# Altium schematic sheet 5.04.51805A02_RKPort.SchDoc(5.04.51805A02_RKPort)
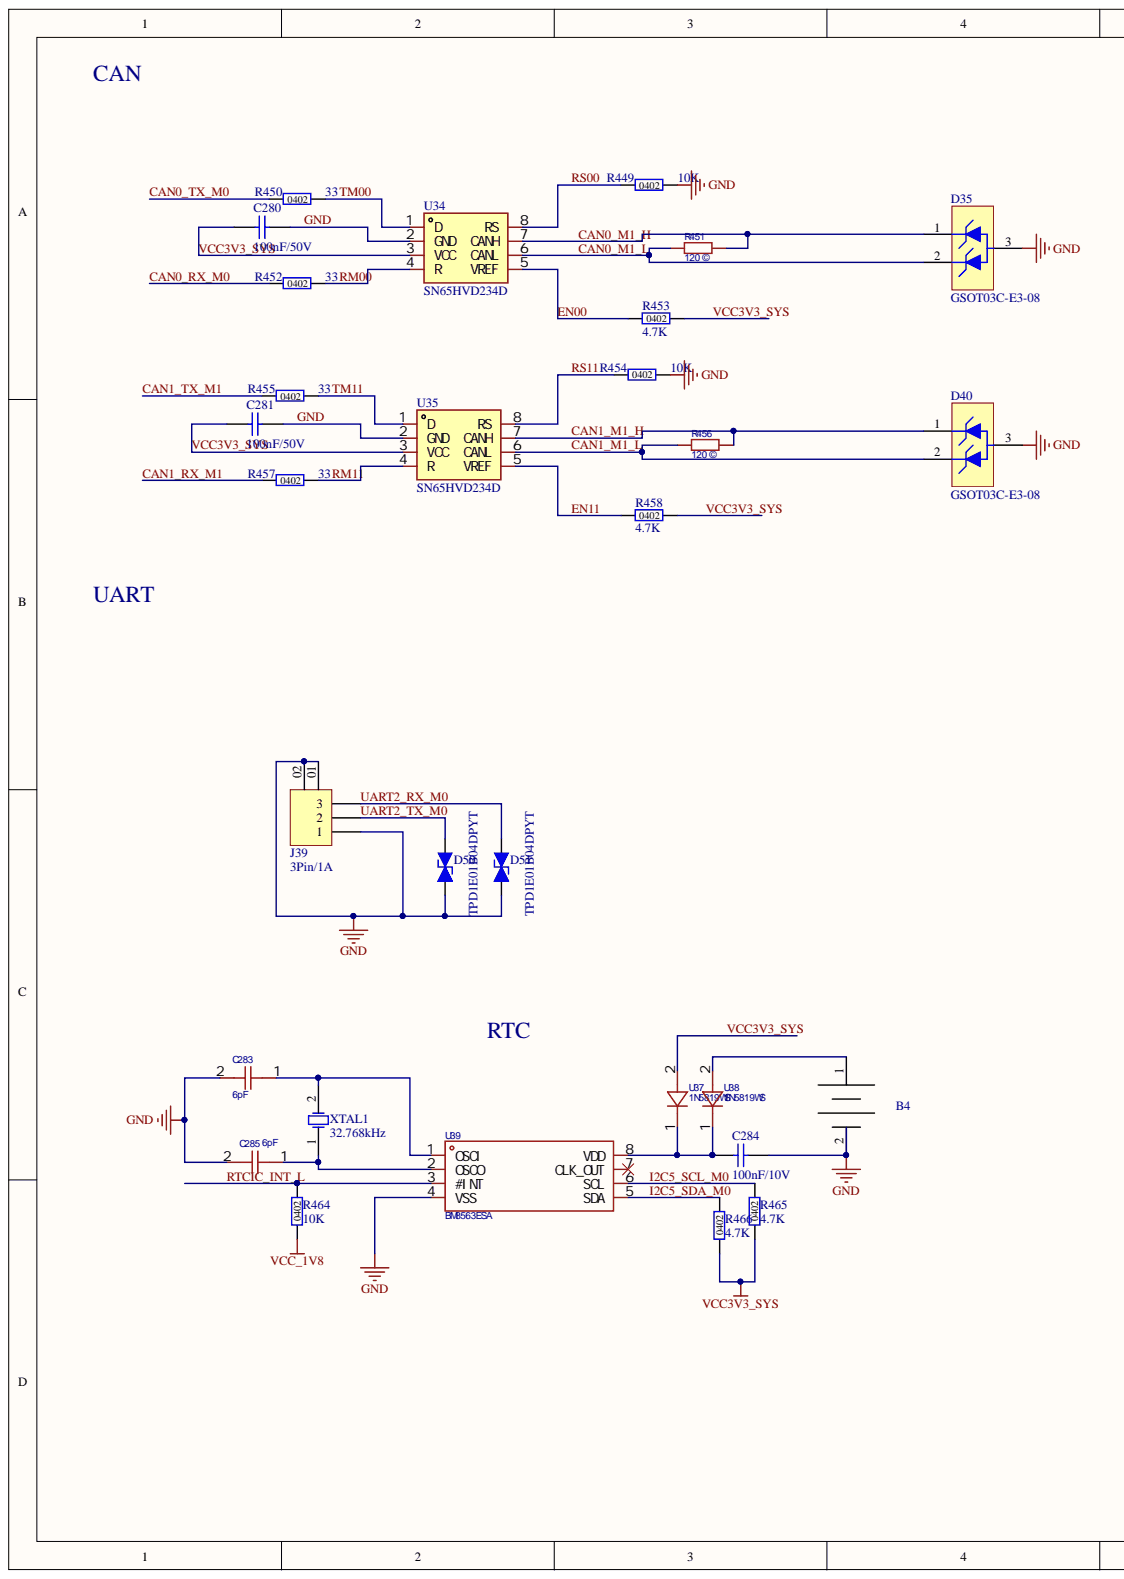
[diagram p0: 5.04.51805A02_RKPort.SchDoc, left]
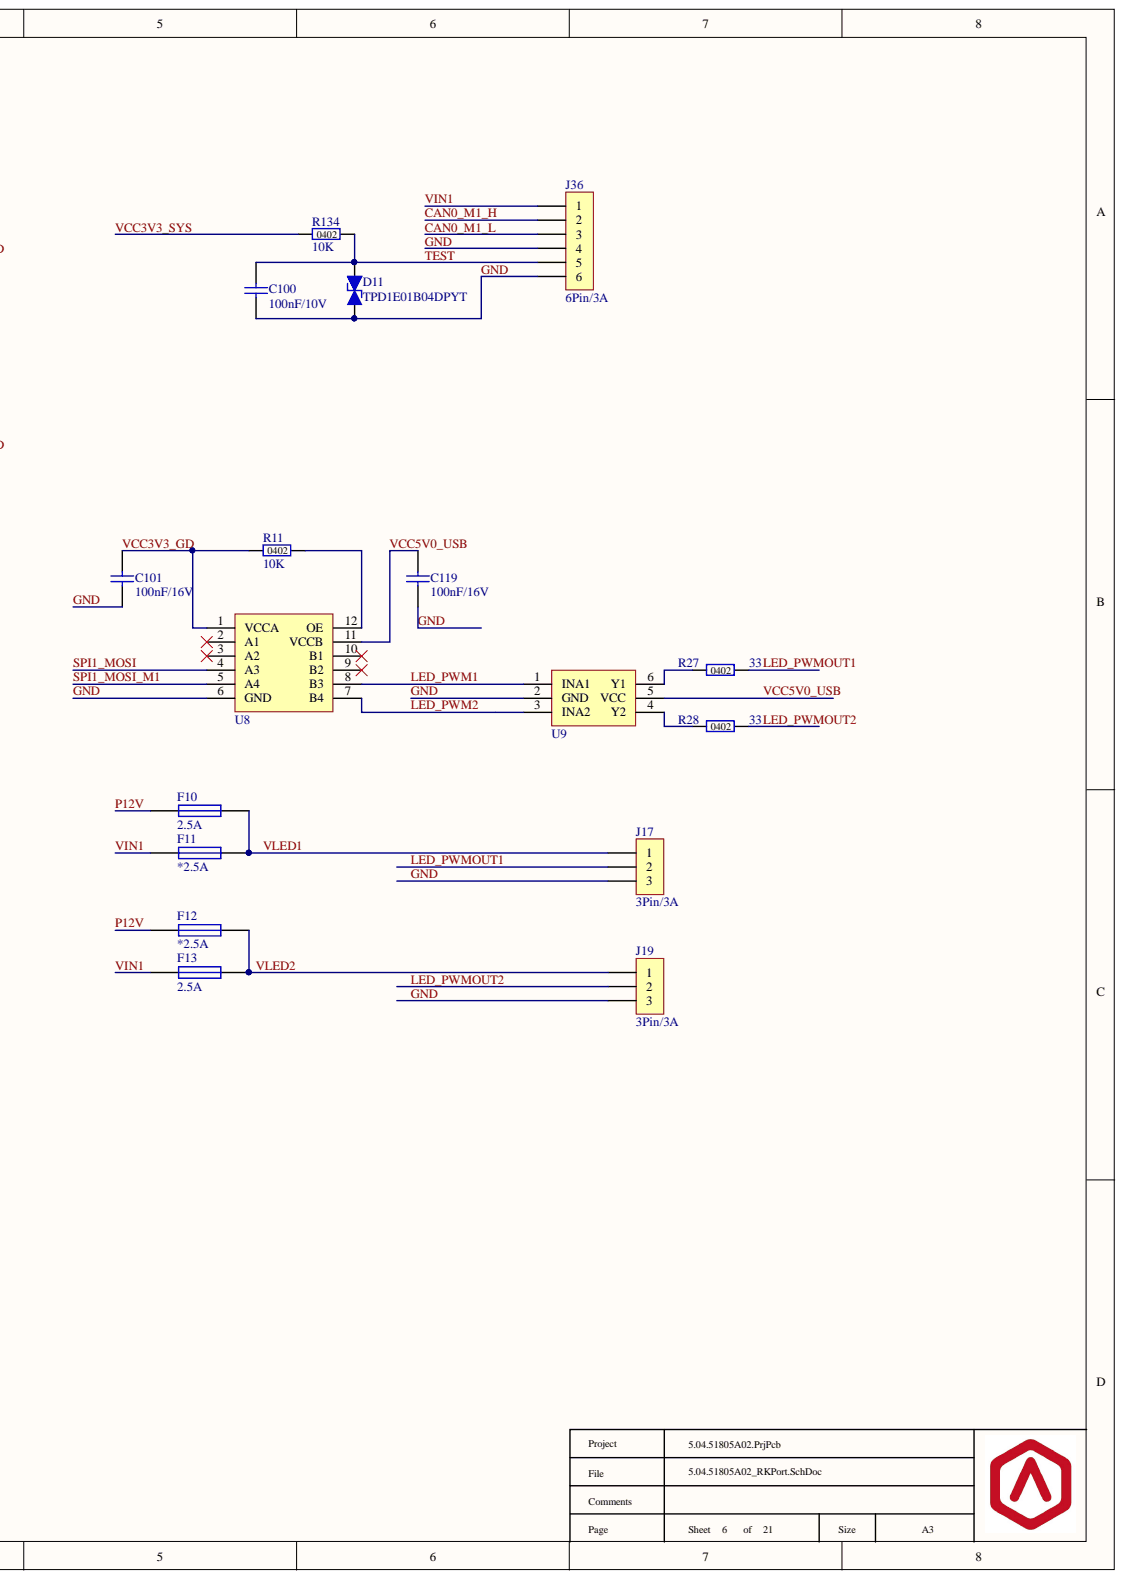
[diagram p1: 5.04.51805A02_RKPort.SchDoc, right]

## Parts
B4  XDCR-1220-006  [BAT-SMD_CR1220-2ZX]  (value not drawn)
C100  100nF/10V  [C0402]
C101  100nF/16V  [C0402]
C119  100nF/16V  [C0402]
C280  100nF/50V  [C0402]
C281  100nF/50V  [C0402]
C283  6pF  [C0402]
C284  100nF/10V  [C0402]
C285  6pF  [C0402]
D11  TPD1E01B04DPYT  [TVS_0402]
D35  GSOT03C-E3-08  [TVS_SOT-23-3]
D40  GSOT03C-E3-08  [TVS_SOT-23-3]
D50  TPD1E01B04DPYT  [TVS_0402]
D51  TPD1E01B04DPYT  [TVS_0402]
F10  2.5A  [F0603]
F11  *2.5A  [F0603]
F12  *2.5A  [F0603]
F13  2.5A  [F0603]
J17  3Pin/3A  [B3B-XH-A(LF)(SN)]
J19  3Pin/3A  [B3B-XH-A(LF)(SN)]
J36  6Pin/3A  [B6B-XH-A(LF)(SN)]
J39  3Pin/1A  [BM03B-GHS-TBT(LF)(SN)]
R11  10K  [R0402]
R27  33  [R0402]
R28  33  [R0402]
R134  10K  [R0402]
R449  10K  [R0402]
R450  33  [R0402]
R451  120Ω  [R0402]
R452  33  [R0402]
R453  4.7K  [R0402]
R454  10K  [R0402]
R455  33  [R0402]
R456  120Ω  [R0402]
R457  33  [R0402]
R458  4.7K  [R0402]
R464  10K  [R0402]
R465  4.7K  [R0402]
R466  4.7K  [R0402]
U8  TXB0104RUTR  [UQFN-12_L2.0-W1.7-P0.40-BL]  (value not drawn)
U9  SN74LVC2G34DBVR  [SOT-23-6_L2.9-W1.6-P0.95-LS2.8-BR]  (value not drawn)
U34  SN65HVD234D  [SOP-8]
U35  SN65HVD234D  [SOP-8]
U37  1N5819WS  [SOD-323_L1.8-W1.3-LS2.5-RD]
U38  1N5819WS  [SOD-323_L1.8-W1.3-LS2.5-RD]
U39  BM8563ESA  [SOP-8_L5.0-W4.0-P1.27-LS6.0-BL]
XTAL1  32.768kHz  [XTAL_126_059_2]

## Nets
VCC_1V8: R464.1
CAN0_M1_H: D35.1 J36.2 R451.1 U34.7(CANH)
CAN0_M1_L: D35.2 J36.3 R451.2 U34.6(CANL)
CAN0_RX_M0: R452.1
CAN0_TX_M0: R450.1
CAN1_M1_H: D40.1 R456.1 U35.7(CANH)
CAN1_M1_L: D40.2 R456.2 U35.6(CANL)
CAN1_RX_M1: R457.1
CAN1_TX_M1: R455.1
EN00: R453.1 U34.5(VREF)
EN11: R458.1 U35.5(VREF)
GND: B4.2 C100.1 C101.1 C119.1 C280.2 C281.2 C283.2 C284.2 C285.2 D11.1 D35.3 D40.3 D50.2 D51.2 J17.3 J19.3 J36.4 J36.6 J39.01 J39.1 J39.02 R449.2 R454.2 U8.6(GND) U9.2(GND) U34.2(GND) U35.2(GND) U39.4(VSS)
I2C5_SCL_M0: R465.2 U39.6(SCL)
I2C5_SDA_M0: R466.2 U39.5(SDA)
LED_PWM1: U8.8(B3) U9.1(INA1)
LED_PWM2: U8.7(B4) U9.3(INA2)
LED_PWMOUT1: J17.2 R27.2
LED_PWMOUT2: J19.2 R28.2
P12V: F10.1 F12.1
RM00: R452.2 U34.4(R)
RM11: R457.2 U35.4(R)
RS00: R449.1 U34.8(RS)
RS11: R454.1 U35.8(RS)
RTCIC_INT_L: R464.2 U39.3(#INT)
SPI1_MOSI: U8.4(A3)
SPI1_MOSI_M1: U8.5(A4)
TEST: C100.2 D11.2 J36.5 R134.2
TM00: R450.2 U34.1(D)
TM11: R455.2 U35.1(D)
UART2_RX_M0: D51.1 J39.3
UART2_TX_M0: D50.1 J39.2
VCC3V3_GD: C101.2 R11.1 U8.1(VCCA)
VCC3V3_SYS: C280.1 C281.1 R134.1 R453.2 R458.2 R465.1 R466.1 U34.3(VCC) U35.3(VCC) U37.2
VCC5V0_USB: C119.2 U8.11(VCCB) U9.5(VCC)
VIN1: F11.1 F13.1 J36.1
VLED1: F10.2 F11.2 J17.1
VLED2: F12.2 F13.2 J19.1
NetB4_1: B4.1 U38.2
NetC283_1: C283.1 U39.1(OSCI) XTAL1.2
NetC284_1: C284.1 U37.1 U38.1 U39.8(VDD)
NetC285_1: C285.1 U39.2(OSCO) XTAL1.1
NetR11_2: R11.2 U8.12(OE)
NetR27_1: R27.1 U9.6(Y1)
NetR28_1: R28.1 U9.4(Y2)

Not connected: U8 2(A1) 3(A2) 9(B2) 10(B1); U39 7(CLK_OUT)

## Library data of the parts
B4 = XDCR-1220-006: Manufacturer1: LX; Code: C7498147; Component Kind: Standard; ManufacturerPartNumber1: XDCR-1220-006; Library Name: Raise3D_General.IntLib
C100 C284 = C0402_100nF_10V: Ceramic Capacitor, 100nF, ±10%, 10V, -55°C~125°C, C0402; Manufacturer1: MuRata; ManufacturerPartNumber1: GRM155R71A104KA01D; Code: 5161C104; Component Kind: Standard; Library Name: Raise3D_Capacitor.IntLib
C101 C119 = C0402_100nF_16V: Ceramic Capacitor, 100nF, ±10%, 16V, -55°C~125°C, C0402; Manufacturer1: Yageo; ManufacturerPartNumber1: CC0402KPX7R7BB104; Code: 5171C104; Component Kind: Standard; Library Name: Raise3D_Capacitor.IntLib
C280 C281 = C0402_100nF_50V: Ceramic Capacitor, 100nF, ±10%, 50V, -55°C~125°C, C0402; Manufacturer1: Yageo; ManufacturerPartNumber1: CC0402KRX7R9BB104; Code: 5191C104; Component Kind: Standard; Manufacturer2: MuRata; ManufacturerPartNumber2: GRM155R71H104KE14D; Library Name: Raise3D_Capacitor.IntLib
C283 C285 = CQ0402BRNPO9BN6R0: 6pF ±0.1，50V; Manufacturer1: 国巨; Component Kind: Standard; ManufacturerPartNumber1: CQ0402BRNPO9BN6R0; Library Name: QQQ.IntLib
D11 D50 D51 = TVS_TPD1E01B04DPYT: TVS二极管,TPD1E01B04DPYT,3.6V,1Channel,+/-17kV,+/-15kV,X1SON-2,-40°C~125°C; Manufacturer1: TexasInstruments; Code: 53040002; Component Kind: Standard; ManufacturerPartNumber1: TPD1E01B04DPYT; Library Name: Raise3D_Diode.IntLib
D35 D40 = TVS_GSOT03C-E3-08: TVS二极管,GSOT03C-E3-08,3.3V,2Channel,+/-30kV,+/-30kV,SOT-23-3,-40°C~125°C; Manufacturer1: Vishay; Code: 53040012; Component Kind: Standard; ManufacturerPartNumber1: GSOT03C-E3-08; Library Name: Raise3D_Diode.IntLib
F10-F13 = Fuse_2.5A: 保险丝,SF-0603S250M-2,32V,2.5A,35A,32mOhms,F0603,-55°C~125°C; Manufacturer1: Bourns; Code: 56010008; Component Kind: Standard; ManufacturerPartNumber1: SF-0603S250M-2; Library Name: Raise3D_Fuse.IntLib
J17 J19 = JW_B3B-XH-A(LF)(SN): 线对板连接器,3PINS,Pitch2.5mm,TH,OR,3A,白,-25°C~85°C; Manufacturer1: JST; Code: 55010013; Component Kind: Standard; Manufacturer2: 红星辰（HXT）; ManufacturerPartNumber1: B3B-XH-A(LF)(SN); ManufacturerPartNumber2: 25000-03A-T0P; Library Name: Raise3D_Connector.IntLib
J36 = JW_B6B-XH-A(LF)(SN): 线对板连接器,6PINS,Pitch2.5mm,TH,OR,3A,白,-25°C~85°C; Manufacturer1: JST; Code: 55010015; Component Kind: Standard; Manufacturer2: 红星辰（HXT）; ManufacturerPartNumber1: B6B-XH-A(LF)(SN); ManufacturerPartNumber2: 25000-06A-T0P; Library Name: Raise3D_Connector.IntLib
J39 = JW_BM03B-GHS-TBT(LF)(SN): 线对板连接器,3PINS,Pitch1.25mm,SMT,OR,1A,米黄,-40°C~85°C; Manufacturer1: JST; Code: 55010002; Component Kind: Standard; Manufacturer2: 健坤; ManufacturerPartNumber1: BM03B-GHS-TBT(LF)(SN); ManufacturerPartNumber2: A1253AWV-03-F1CB1-P; Library Name: Raise3D_Connector.IntLib
R11 R134 R449 R454 R464 = R0402_10K: Resistor 10Kohm,1%,1/16W,R0402; Manufacturer1: Yageo; ManufacturerPartNumber1: RC0402FR-0710KL; Code: 5001010K; Component Kind: Standard; Manufacturer2: Samsung; ManufacturerPartNumber2: RC1005F103CS; Library Name: Raise3D_Resistor.IntLib
R27 R28 R450 R452 R455 R457 = R0402_33: Resistor 33ohm,1%,1/16W,R0402; Manufacturer1: Yageo; ManufacturerPartNumber1: RC0402FR-0733RL; Code: 5001033R; Component Kind: Standard; Library Name: Raise3D_Resistor.IntLib
R451 R456 = RC0402FR-07120RL: 厚膜电阻 120Ω ±1% 62.5mW; Manufacturer1: YAGEO(国巨); Component Kind: Standard; ManufacturerPartNumber1: RC0402FR-07120RL; Library Name: QQQ.IntLib
R453 R458 R465 R466 = R0402_4.7K: Resistor 4.7Kohm,5%,1/16W,R0402; Manufacturer1: Yageo; ManufacturerPartNumber1: RC0402JR-074K7L; Code: 501104K7; Component Kind: Standard; Manufacturer2: Samsung; ManufacturerPartNumber2: RCS1005J472CS; Library Name: Raise3D_Resistor.IntLib
U8 = TXB0104RUTR: 电平转换器,1.65~5.5V,4ports,-40℃~85℃,UQFN-12; Manufacturer1: TI; Code: C105266; Component Kind: Standard; ManufacturerPartNumber1: TXB0104RUTR; Library Name: Raise3D_General.IntLib
U9 = SN74LVC2G34DBVR: 缓冲器,1.65~5.5V,2ports,-40℃~85℃,SOT23-6; Manufacturer1: UMW; Code: C7394039; Component Kind: Standard; ManufacturerPartNumber1: SN74LVC2G34DBVR; Library Name: Raise3D_General.IntLib
U34 U35 = DRV_SN65HVD234D: 接口IC,SN65HVD234D,CAN,1Port(s),3 ~ 3.6V,8-SOIC,-40°C~125°C; Manufacturer1: TexasInstruments; Code: 59080005; Component Kind: Standard; ManufacturerPartNumber1: SN65HVD234D; Library Name: Raise3D_IC.IntLib
U37 U38 = 1N5819WS: 600mV@200mA,电压:40V 电流:350mA; Manufacturer1: HXY MOSFET(华轩阳电子); Component Kind: Standard; ManufacturerPartNumber1: 1N5819WS; Library Name: QQQ.IntLib
U39 = BM8563ESA: RTC ,1.2V~5.5V,I2C; Manufacturer1: GATEMODE(捷茂微); Component Kind: Standard; ManufacturerPartNumber1: BM8563ESA; Library Name: QQQ.IntLib
XTAL1 = XTAL_32.768kHz_00: Crystal,FC-13532.7680KA-AG3,32.768kHz,7pF,50kOhm,2-SMD,3.2mmX1.5mm,-40°C~85°C; Manufacturer1: EPSON; ManufacturerPartNumber1: FC-13532.7680KA-E3; Code: 58010004; Component Kind: Standard; Library Name: Raise3D_Crystal.IntLib
